# Altium schematic sheet pixracer_imu.SchDoc(pixracer_imu)
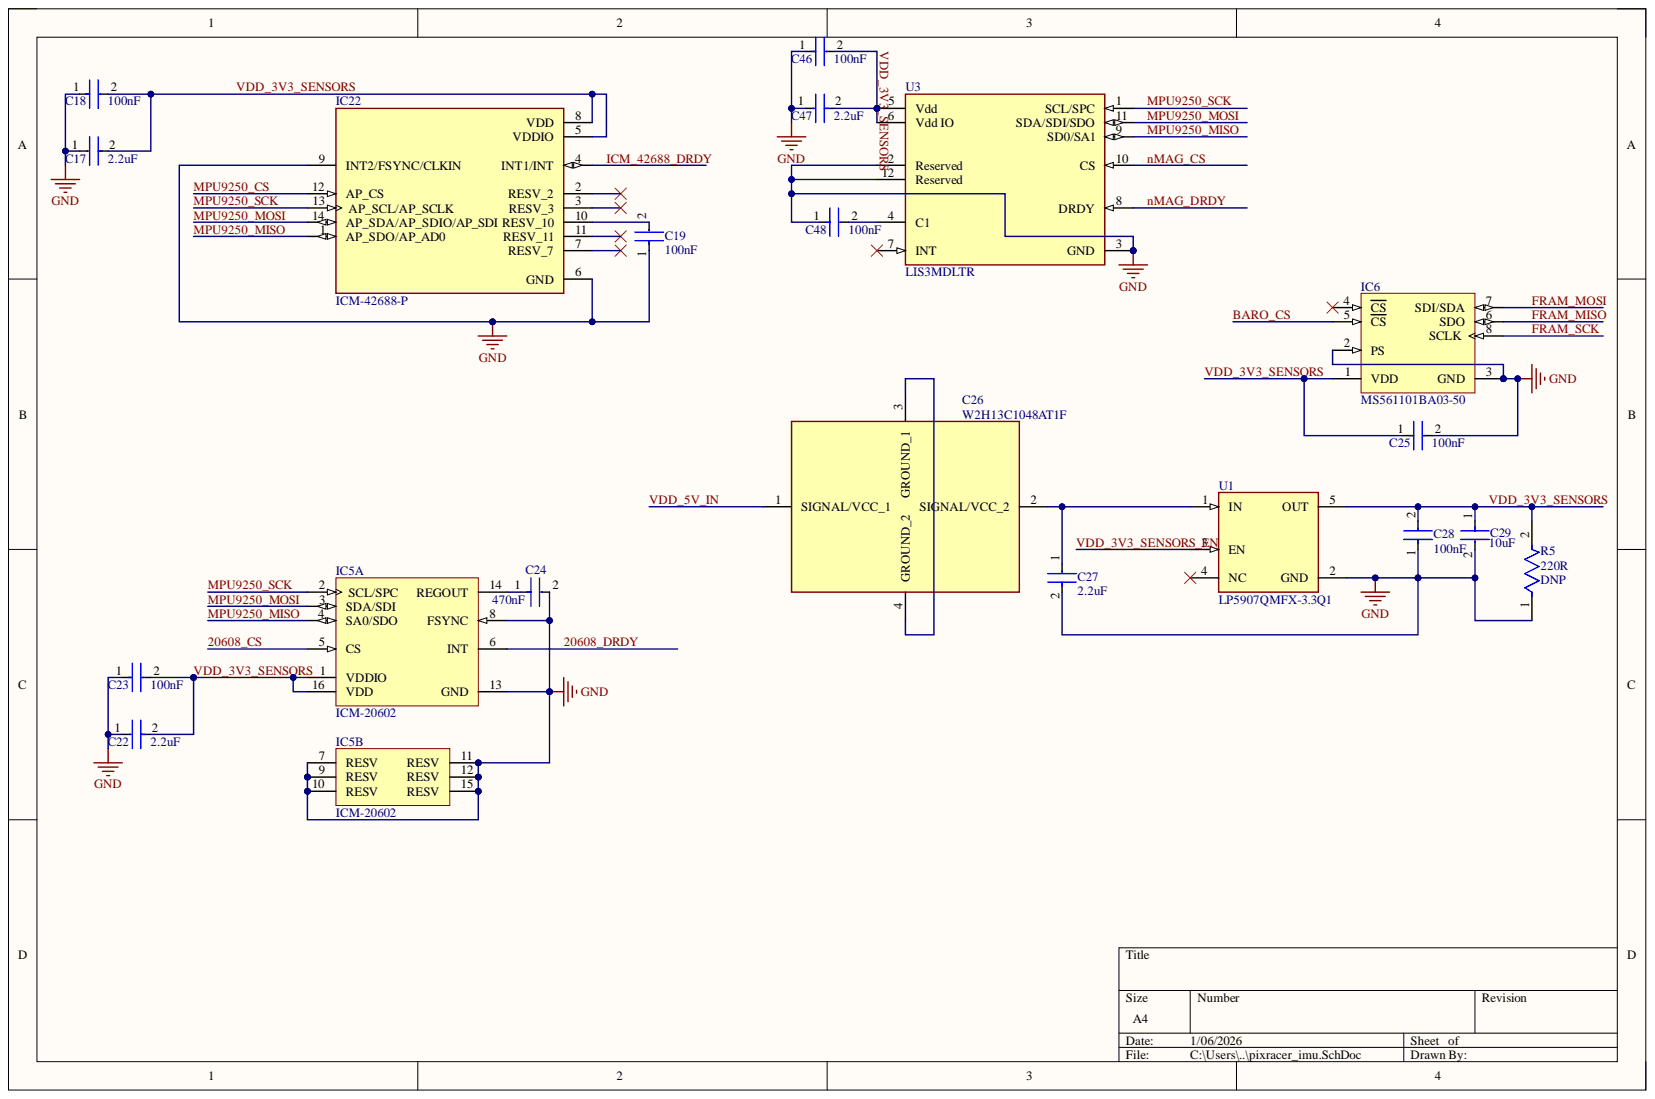
[diagram p0: pixracer_imu.SchDoc]

## Parts
C17  Capacitance: 2.2uF  [FP-C1608-080-0_1-MFG]  (hidden comment: C1608X7R1A225K080AC)
C18  Capacitance: 100nF  [FP-0603-L_1_6_0_15-W_0_81-IPC_B]  (hidden comment: 0603ZC104MAT2A)
C19  Capacitance: 100nF  [FP-0603-L_1_6_0_15-W_0_81-IPC_B]  (hidden comment: 0603ZC104MAT2A)
C22  Capacitance: 2.2uF  [FP-C1608-080-0_1-MFG]  (hidden comment: C1608X7R1A225K080AC)
C23  Capacitance: 100nF  [FP-0603-L_1_6_0_15-W_0_81-IPC_B]  (hidden comment: 0603ZC104MAT2A)
C24  Capacitance: 470nF  [FP-CL10-IPC_B]  (hidden comment: CL10B474KP8NNNC)
C25  Capacitance: 100nF  [FP-0603-L_1_6_0_15-W_0_81-IPC_B]  (hidden comment: 0603ZC104MAT2A)
C26  W2H13C1048AT1F  [W2H13C1048AT1A]
C27  Capacitance: 2.2uF  [FP-C1608-080-0_1-MFG]  (hidden comment: C1608X7R1A225K080AC)
C28  Capacitance: 100nF  [FP-0603-L_1_6_0_15-W_0_81-IPC_B]  (hidden comment: 0603ZC104MAT2A)
C29  Capacitance: 10uF  [FP-CL10-IPC_B]  (hidden comment: CL10A106KP8NNND)
C46  Capacitance: 100nF  [FP-0603-L_1_6_0_15-W_0_81-IPC_B]  (hidden comment: 0603ZC104MAT2A)
C47  Capacitance: 2.2uF  [FP-C1608-080-0_1-MFG]  (hidden comment: C1608X7R1A225K080AC)
C48  Capacitance: 100nF  [FP-0603-L_1_6_0_15-W_0_81-IPC_B]  (hidden comment: 0603ZC104MAT2A)
IC5  ICM-20602  [INSE-I62-16]  (parts A,B)
IC6  MS561101BA03-50  [MSI-MS561101BA03-50-8_V]
IC22  ICM-42688-P  [PQFN50P300X250X97-14N]
R5  DNP  Resistance: 220R  [FP-CRCW0603-e3-MFG]
U1  LP5907QMFX-3.3Q1  [FP-DBV0005A-MFG]
U3  LIS3MDLTR  [FP-VFLGA-12-8365767-MFG]

## Nets
GND: C17.1 C18.1 C19.1 C22.1 C23.1 C24.2 C25.2 C27.2 C28.1 C29.2 C46.1 C47.1 C48.1 IC5.7(RESV) IC5.8(FSYNC) IC5.9(RESV) IC5.10(RESV) IC5.11(RESV) IC5.12(RESV) IC5.13(GND) IC5.15(RESV) IC6.2(PS) IC6.3(GND) IC22.6(GND) IC22.9(INT2/FSYNC/CLKIN) R5.1 U1.2(GND) U3.2(Reserved) U3.3(GND) U3.12(Reserved)
20608_CS: IC5.5(CS)
20608_DRDY: IC5.6(INT)
BARO_CS: IC6.5(CS)
FRAM_MISO: IC6.6(SDO)
FRAM_MOSI: IC6.7(SDI/SDA)
FRAM_SCK: IC6.8(SCLK)
ICM_42688_DRDY: IC22.4(INT1/INT)
MPU9250_CS: IC22.12(AP_CS)
MPU9250_MISO: IC5.4(SA0/SDO) IC22.1(AP_SDO/AP_AD0) U3.9(SD0/SA1)
MPU9250_MOSI: IC5.3(SDA/SDI) IC22.14(AP_SDA/AP_SDIO/AP_SDI) U3.11(SDA/SDI/SDO)
MPU9250_SCK: IC5.2(SCL/SPC) IC22.13(AP_SCL/AP_SCLK) U3.1(SCL/SPC)
nMAG_CS: U3.10(CS)
nMAG_DRDY: U3.8(DRDY)
VDD_3V3_SENSORS: C17.2 C18.2 C22.2 C23.2 C25.1 C28.2 C29.1 C46.2 C47.2 IC5.1(VDDIO) IC5.16(VDD) IC6.1(VDD) IC22.5(VDDIO) IC22.8(VDD) R5.2 U1.5(OUT) U3.5(Vdd) U3.6(Vdd_IO)
VDD_3V3_SENSORS_EN: U1.3(EN)
VDD_5V_IN: C26.1(SIGNAL/VCC_1)
NetC19_2: C19.2 IC22.10(RESV_10)
NetC24_1: C24.1 IC5.14(REGOUT)
NetC26_2: C26.2(SIGNAL/VCC_2) C27.1 U1.1(IN)
NetC26_3: C26.3(GROUND_1) C26.4(GROUND_2)
NetC48_2: C48.2 U3.4(C1)

Not connected: IC6 4(CS); IC22 2(RESV_2) 3(RESV_3) 7(RESV_7) 11(RESV_11); U1 4(NC); U3 7(INT)

## Library data of the parts
C17 C22 C27 C47 = CMP-08246-006835-1: Multilayer Ceramic Capacitors 2.2μF ±10% 10V X7R SMD 0603; Case Code (Imperial): 0603; Case Code (Metric): 1608; Case/Package: 0603; Component Kind: Standard; Construction: Flat; Depth: 0.8mm; Dielectric: X7R; Dielectric Material: Ceramic; Height: 0.8mm; Lead Free: Lead Free; Length: 1.6mm; Library Name: Altium Content Vault; Max Operating Temperature: 125°C; Min Operating Temperature: -55°C; Mount: Surface Mount; Package Quantity: 4000; Packaging: Tape and Reel; REACH SVHC: No SVHC; Resistor Type: Ceramic; RoHS Compliant: Yes; Series: C; Termination: SMD/SMT; Thickness: 0.037inch; Tolerance: 10%; Voltage Rating: 10V; Voltage Rating (DC): 10V; Weight: 7.1E-05oz; Width: 0.031inch
C18 C19 C23 C25 C28 C46 C48 = CMP-2006-04550-2: General Purpose Ceramic Capacitor, 0603, 100nF, 20%, X7R, 15%, 10V; Case Code (Imperial): 0603; Case Code (Metric): 1608; Case/Package: 0603; Component Kind: Standard; Construction: Flat; Depth: 0.81mm; Dielectric: X7R; Lead Free: Lead Free; Lead Pitch: 0.014inch; Length: 1.6mm; Library Name: Altium Content Vault; Max Operating Temperature: 125°C; Min Operating Temperature: -55°C; Mount: Surface Mount; Number of Pins: 2; Package Quantity: 4000; Packaging: Tape and Reel; Radiation Hardening: No; RoHS Compliant: Yes; Series: -; Termination: SMD/SMT; Thickness: 0.035inch; Tolerance: 20%; Voltage Rating: 10V; Voltage Rating (DC): 10V; Width: 0.032inch
C24 = CMP-13271-000054-1: Cap Ceramic 470nF 10V X7R ±10% Pad SMD 0603 +125°C T/R; Case Code (Imperial): 0603; Case Code (Metric): 1608; Case/Package: 0603; Component Kind: Standard; Depth: 0.8mm; Dielectric: X7R; Height: 0.8mm; Lead Free: Lead Free; Length: 1.6mm; Library Name: Altium Content Vault; Max Operating Temperature: 125°C; Min Operating Temperature: -55°C; Mount: Surface Mount; Package Quantity: 1; Packaging: Tape and Reel; Radiation Hardening: No; Thickness: 0.035inch; Tolerance: 10%; Voltage: 10V; Voltage Rating: 10V; Voltage Rating (DC): 10V; Width: 0.031inch
C26 = W2H13C1048AT1F: Capacitor; Manufacturer_Name: AVX; Arrow Part Number: W2H13C1048AT1F; Arrow Price/Stock: https://www.arrow.com/en/products/w2h13c1048at1f/avx; Component Kind: Standard; Datasheet Link: https://componentsearchengine.com/Datasheets/1/W2H13C1048AT1F.pdf; Height: 1mm; Manufacturer_Part_Number: W2H13C1048AT1F; Mouser Part Number: 581-W2H13C1048AT1F; Mouser Price/Stock: https://www.mouser.com/Search/Refine.aspx?Keyword=581-W2H13C1048AT1F
C29 = CMP-13271-003245-1: Cap Ceramic 10uF 10V X5R ±10% Pad SMD 0603 +85°C T/R; Case/Package: 0603; Component Kind: Standard; Depth: 0.8mm; Dielectric: X5R; Height: 0.8mm; Lead Free: Lead Free; Length: 1.6mm; Library Name: Altium Content Vault; Max Operating Temperature: 85°C; Min Operating Temperature: -55°C; Mount: Surface Mount; Package Quantity: 10000; Packaging: Tape and Reel; Radiation Hardening: No; Thickness: 0.035inch; Tolerance: 10%; Voltage Rating: 10V; Voltage Rating (DC): 10V; Width: 0.031inch
IC5 = CMP-2000-07589-1: High Performance 6-Axis MEMS MotionTracking(TM) Device, 1.71 to 3.45 V, -40 to 85 degC, 16-Pin LGA, RoHS; Manufacturer: InvenSense; Output Type: I2C; Sensor Type: Temperature; Component Kind: Standard; Datasheet URL: http://www.invensense.com/wp-content/uploads/2016/10/DS-000176-ICM-20602-v1.0.pdf; Datasheet Version: Rev. 1.0, 10/2016; Library Name: Altium Content Vault; Manufacturer URL: http://www.invensense.com/; Max Operating Temperature: 85degC; Min Operating Temperature: -40degC; Mounting Technology: SurfaceMount; Package Description: 16-Pin Land Grid Array, Body 3 x 3 mm, Pitch 0.5 mm; Package Reference: I62-16; RoHSCompliant: True
IC6 = CMP-2000-05251-1: Barometric Pressure Sensor, with Stainless Steel Cap, 1.8 to 3.6 V, -45 to 85 degC, 8-Pin QFN, RoHS, Tape and Reel; Manufacturer: Measurement Specialties; Connection Size: 0.5mm; No. of Pins: 8; Operating Pressure Max: 1200mbar; Operating Pressure Min: 10mbar; Supply Current: 12.5µA; Supply Voltage Max: 3.6V; Supply Voltage Min: 1.8V; SVHC: NoSVHC(20-Jun-2013); Component Kind: Standard; Datasheet URL: http://www.te.com/commerce/DocumentDelivery/DDEController?Action=srchrtrv&DocNm=MS5611-01BA03&DocType=Data+Sheet&DocLang=English&DocFormat=pdf&PartCntxt=CAT-BLPS0036; Datasheet Version: Sep-15; Library Name: Altium Content Vault; Manufacturer URL: http://www.meas-spec.com/; Operating Temperature Max: 85degC; Operating Temperature Min: -40degC; Package Description: 8-Pin Surface Mount Device, Body 5 x 3 mm, Pitch 1.25 mm; Package Reference: MS561101BA03-50-8; Pressure Type: Barometric; Sensor Case Style: QFN
IC22 = ICM-42688-P: Accelerometer, Gyroscope, 6 Axis Sensor Output; Availability: Unavailable; Component Kind: Standard; Library Name: ICM-42688-P.IntLib; MP: ICM-42688-P; Package: None; Price: None
R5 = CMP-02407-003555-1: RES Thick Film, 220Ω, 1%, 0.1W, 100ppm/°C, 0603; Max Power Dissipation: 100mW; Voltage Rating: 75V; Case Code (Imperial): 0603; Case Code (Metric): 1608; Case/Package: 0603; Component Kind: Standard; Composition: Thick Film; Depth: 0.85mm; Features: Automotive AEC-Q200; Height: 0.02inch; Lead Free: Lead Free; Length: 1.55mm; Library Name: Altium Content Vault; Max Operating Temperature: 155°C; Military Standard: Not; Min Operating Temperature: -55°C; Number of Terminations: 2; Package Quantity: 10000; Packaging: Tape and Reel; Power Rating: 100mW; Temperature Coefficient: 100ppm/°C; Termination: SMD/SMT; Tolerance: 1%; Weight: 7.1E-05oz; Width: 0.85mm
U1 = CMP-04917-000018-1: IC REG LINEAR 3.3V 250MA SOT23-5; Manufacturer: Texas Instruments; Automotive Grade: Grade 1; Dropout Voltage: 120mV; Max Junction Temp: +125°C; Max Supply Voltage: 5.5V; Min Supply Voltage: 2.2V; Nominal Supply Current: 12-250uA; Number Of Outputs: 1; Output Type: Fixed; Standoff Height: 0mm; Automotive: Yes; Category: IC; Component Kind: Standard; ComponentLink2Description: Datasheet; ComponentLink2URL: http://www.ti.com/lit/ds/symlink/lp5907-q1.pdf; Device Class L1: Integrated Circuits (ICs); Device Class L2: Power Management ICs; Device Class L3: Voltage Regulators - Linear; Digikey Description: IC REG LINEAR 3.3V 250MA SOT23-5; Height: 1.45mm; Lead Free: Yes; Library Name: Altium Content Vault; Manufacturer Part Number: LP5907QMFX-3.3Q1; Mouser Description: Automotive 250-mA ultra-low-noise low-IQ low-dropout (LDO) linear regulator 5-SOT-23 -40 to 125; Octopart: https://octopart.com/lp5907qmfx-3.3q1-texas+instruments-50417899; Output Current: 250mA; Output Voltage: 3.3V; Package: DBV0005A; RoHS: TRUE; Temperature: -40°C to +125°C; Temperature Range High: +125°C; Temperature Range Low: -40°C
U3 = CMP-2000-07463-2: SENSOR MR I2C/SPI 12LGA; Manufacturer: STMicroelectronics; Max Supply Voltage: 3.6V; Min Supply Voltage: 1.71V; Operating Supply Current: 40-270uA; Sensing Method: Magnetic; Sensor Output: I2C,SPI; Automotive: No; Category: IC; Component Kind: Standard; ComponentLink2Description: Datasheet; ComponentLink2URL: https://www.st.com/resource/en/datasheet/lis3mdl.pdf; Device Class L1: Sensors; Device Class L2: Magnetic Sensors; Device Class L3: unset; Digikey Description: SENSOR MR I2C/SPI 12LGA; Footprint Url: https://www.st.com/content/ccc/resource/technical/document/technical_note/73/17/9c/16/dd/96/4a/01/CD00134799.pdf/files/CD00134799.pdf/jcr:content/translations/en.CD00134799.pdf; Height: 1mm; Lead Free: Yes; Library Name: Altium Content Vault; Manufacturer Part Number: LIS3MDLTR; Mouser Description: Board Mount Hall Effect / Magnetic Sensors Ultra Lo-Pwr Hi Perf 3-Axis 1.9-3.6V; Octopart: https://octopart.com/lis3mdltr-stmicroelectronics-29403125; Package: VFLGA-12-8365767; RoHS: TRUE; Temperature: -40°C to +85°C; Temperature Range High: +85°C; Temperature Range Low: -40°C
